# Altium schematic sheet Sheet1.SchDoc
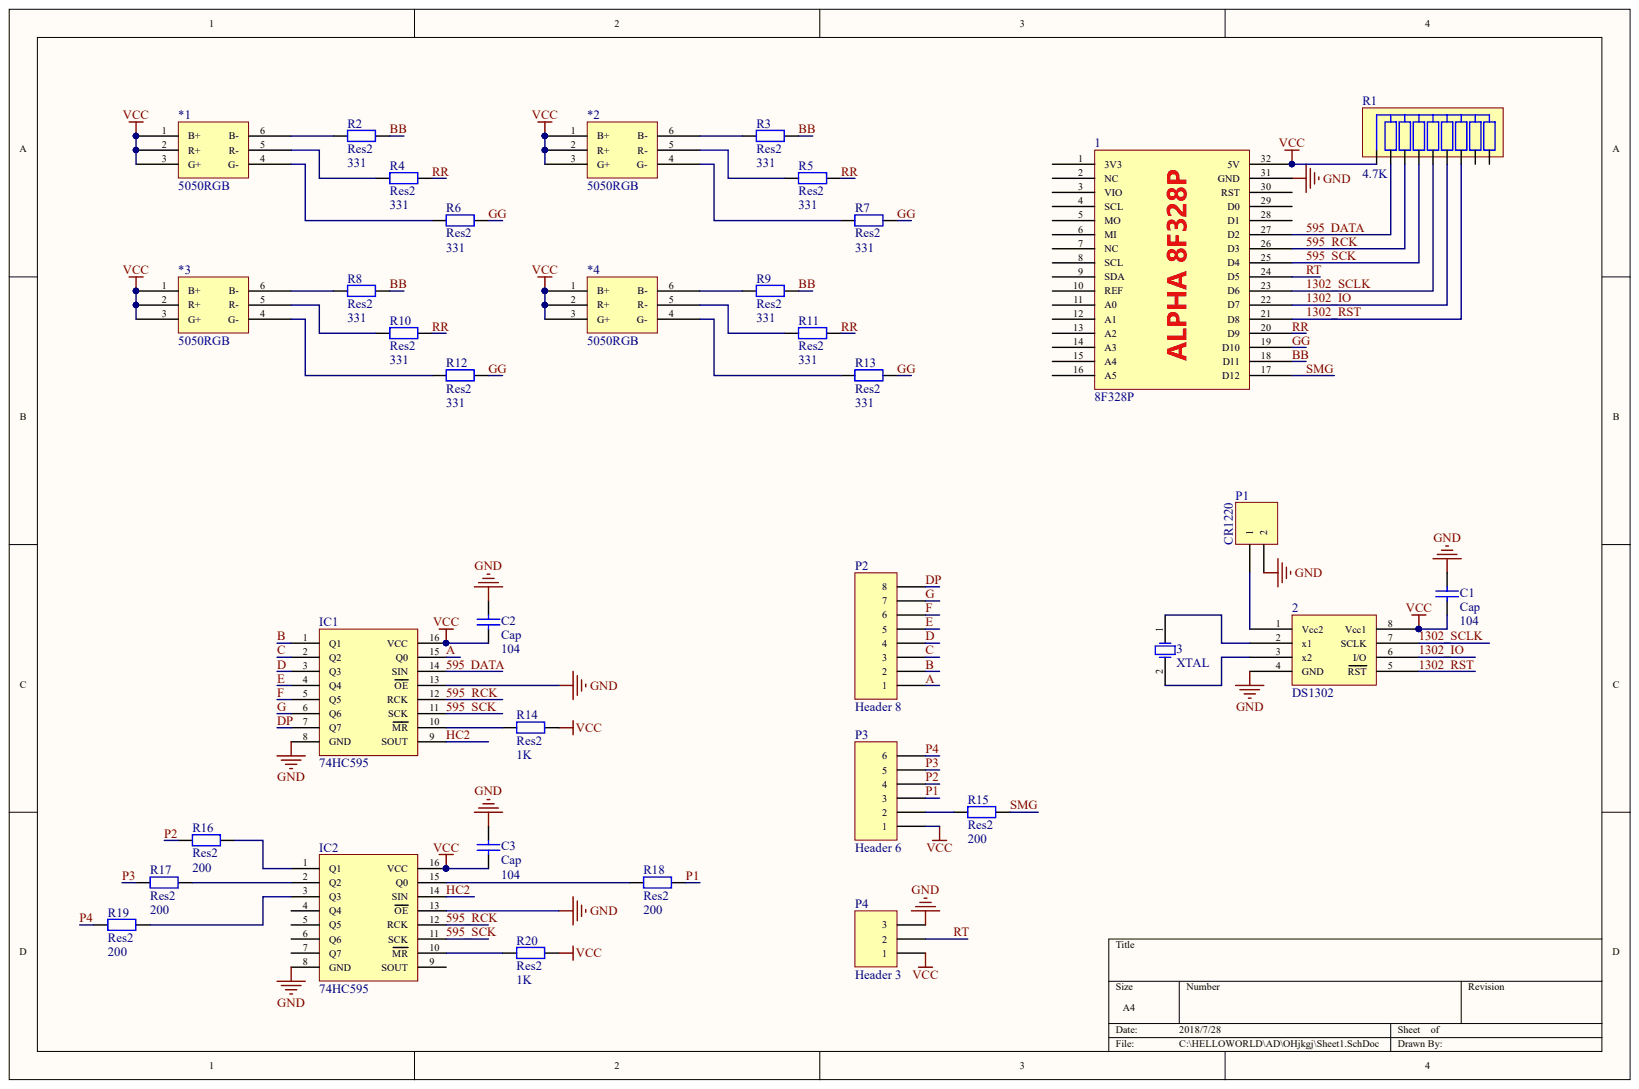
[diagram p0: Sheet1.SchDoc]

## Parts
1  8F328P  [ALPHA_8F328P]
2  DS1302  [DIP-8]
3  XTAL  [R38]
*1  5050RGB  [5050RGBLED]
*2  5050RGB  [5050RGBLED]
*3  5050RGB  [5050RGBLED]
*4  5050RGB  [5050RGBLED]
C1  Cap  value 104  [C104]
C2  Cap  value 104  [C104]
C3  Cap  value 104  [C104]
IC1  74HC595  [DIP-16]
IC2  74HC595  [DIP-16]
P1  CR1220  [CR1220]
P2  Header 8  [HDR1X8]
P3  Header 6  [HDR1X6]
P4  Header 3  [HDR1X3]
R1  4.7K  [HDR1X9]
R2  Res2  [6-0805_N]
R3  Res2  [6-0805_N]
R4  Res2  value 331  [6-0805_N]
R5  Res2  value 331  [6-0805_N]
R6  Res2  value 331  [6-0805_N]
R7  Res2  value 331  [6-0805_N]
R8  Res2  [6-0805_N]
R9  Res2  [6-0805_N]
R10  Res2  value 331  [6-0805_N]
R11  Res2  value 331  [6-0805_N]
R12  Res2  value 331  [6-0805_N]
R13  Res2  value 331  [6-0805_N]
R14  Res2  value 1K  [6-0805_N]
R15  Res2  value 200  [6-0805_N]
R16  Res2  [6-0805_N]
R17  Res2  value 200  [6-0805_N]
R18  Res2  value 200  [6-0805_N]
R19  Res2  value 200  [6-0805_N]
R20  Res2  value 1K  [6-0805_N]

## Nets
GND: 1.31(GND) 2.4(GND) C1.1 C2.1 C3.1 IC1.8(GND) IC1.13(OE) IC2.8(GND) IC2.13(OE) P1.2 P4.3
VCC: 1.32(5V) 2.8(Vcc1) *1.1(B+) *1.2(R+) *1.3(G+) *2.1(B+) *2.2(R+) *2.3(G+) *3.1(B+) *3.2(R+) *3.3(G+) *4.1(B+) *4.2(R+) *4.3(G+) C1.2 C2.2 C3.2 IC1.16(VCC) IC2.16(VCC) P3.1 P4.1 R1.1 R14.2 R20.2
595_DATA: 1.27(D2) IC1.14(SIN) R1.2
595_RCK: 1.26(D3) IC1.12(RCK) IC2.12(RCK) R1.3
595_SCK: 1.25(D4) IC1.11(SCK) IC2.11(SCK) R1.4
1302_IO: 1.22(D7) 2.6(I/O) R1.6
1302_RST: 1.21(D8) 2.5(RST) R1.7
1302_SCLK: 1.23(D6) 2.7(SCLK) R1.5
A: IC1.15(Q0) P2.1
B: IC1.1(Q1) P2.2
BB: 1.18(D11) R2.2 R3.2 R8.2 R9.2
C: IC1.2(Q2) P2.3
D: IC1.3(Q3) P2.4
DP: IC1.7(Q7) P2.8
E: IC1.4(Q4) P2.5
F: IC1.5(Q5) P2.6
G: IC1.6(Q6) P2.7
GG: 1.19(D10) R6.2 R7.2 R12.2 R13.2
HC2: IC1.9(SOUT) IC2.14(SIN)
P1: P3.3 R18.2
P2: P3.4 R16.1
P3: P3.5 R17.1
P4: P3.6 R19.1
RR: 1.20(D9) R4.2 R5.2 R10.2 R11.2
RT: 1.24(D5) P4.2
SMG: 1.17(D12) R15.1
Net2_1: 2.1(Vcc2) P1.1
Net2_2: 2.2(x1) 3.1
Net2_3: 2.3(x2) 3.2
Net*1_4: *1.4(G-) R6.1
Net*1_5: *1.5(R-) R4.1
Net*1_6: *1.6(B-) R2.1
Net*2_4: *2.4(G-) R7.1
Net*2_5: *2.5(R-) R5.1
Net*2_6: *2.6(B-) R3.1
Net*3_4: *3.4(G-) R12.1
Net*3_5: *3.5(R-) R10.1
Net*3_6: *3.6(B-) R8.1
Net*4_4: *4.4(G-) R13.1
Net*4_5: *4.5(R-) R11.1
Net*4_6: *4.6(B-) R9.1
NetIC1_10: IC1.10(MR) R14.1
NetIC2_1: IC2.1(Q1) R16.2
NetIC2_2: IC2.2(Q2) R17.2
NetIC2_3: IC2.3(Q3) R19.2
NetIC2_10: IC2.10(MR) R20.1
NetIC2_15: IC2.15(Q0) R18.1
NetP3_2: P3.2 R15.2

Not connected: 1 1(3V3) 2(NC) 3(VIO) 4(SCL) 5(MO) 6(MI) 7(NC) 8(SCL) 9(SDA) 10(REF) 11(A0) 12(A1) 13(A2) 14(A3) 15(A4) 16(A5) 28(D1) 29(D0) 30(RST); IC2 4(Q4) 5(Q5) 6(Q6) 7(Q7) 9(SOUT); R1 8 9

## Library data of the parts
1 *1-*4 = Component_1: Component Kind: Standard
  1: Library Name: ALPHA_8F328P.SchLib
  *1-*4: Library Name: 5050RGBLED.SchLib
3 = XTAL: Crystal Oscillator; Component Kind: Standard; LatestRevisionDate: 17-Jul-2002; LatestRevisionNote: Re-released for DXP Platform.; Library Name: Miscellaneous Devices.IntLib; PackageDescription: Crystal, Thru-Hole; 2 Leads; Body 3.1 x 8.2 mm (Dia.xH); PackageReference: R38; Published: 8-Jun-2000; Simulation: XTAL; Publisher: Altium Limited
C1-C3 = Cap: Capacitor; Component Kind: Standard; LatestRevisionDate: 17-Jul-2002; LatestRevisionNote: Re-released for DXP Platform.; Library Name: Miscellaneous Devices.IntLib; PackageDescription: Radial Cap, Thru-Hole; 2 Leads; 0.3 in Pin Spacing; PackageReference: RAD-0.3; Published: 8-Jun-2000; Signal Integrity: Cap; Simulation: CAP; Publisher: Altium Limited
IC1 IC2 = 74HC595: 8位移位寄存器; 版权归属: 源创客; BOM名称: 8位移位寄存器; Component Kind: Standard; Library Name: 1.2   - 74系列芯片.SchLib; 学习资源: www.ooocke.com
P1 = Header 2: Header, 2-Pin; Component Kind: Standard; LatestRevisionDate: 17-Jul-2002; LatestRevisionNote: Re-released for DXP Platform.; Library Name: Miscellaneous Connectors.IntLib; Signal Integrity: Connector; Publisher: Altium Limited
P2 = Header 8: Header, 8-Pin; Component Kind: Standard; LatestRevisionDate: 17-Jul-2002; LatestRevisionNote: Re-released for DXP Platform.; Library Name: Miscellaneous Connectors.IntLib; Signal Integrity: Connector; Publisher: Altium Limited
P3 = Header 6: Header, 6-Pin; Component Kind: Standard; LatestRevisionDate: 17-Jul-2002; LatestRevisionNote: Re-released for DXP Platform.; Library Name: Miscellaneous Connectors.IntLib; Signal Integrity: Connector; Publisher: Altium Limited
P4 = Header 3: Header, 3-Pin; Component Kind: Standard; LatestRevisionDate: 17-Jul-2002; LatestRevisionNote: Re-released for DXP Platform.; Library Name: Miscellaneous Connectors.IntLib; Signal Integrity: Connector; Publisher: Altium Limited
R1 = R SIP9: 单列直插排阻; 版权归属: 源创客; BOM名称: 单列直插排阻; Component Kind: Standard; Library Name: 3.1   - 电阻-电容-电感.SchLib; 学习资源: www.ooocke.com
R2 R3 R8 R9 = Res2: Resistor; Value: 331; Component Kind: Standard; LatestRevisionDate: 17-Jul-2002; LatestRevisionNote: Re-released for DXP Platform.; Library Name: Miscellaneous Devices.IntLib; PackageDescription: Axial Device, Thru-Hole; 2 Leads; 0.4 in Pin Spacing; PackageReference: AXIAL-0.4; Published: 8-Jun-2000; Signal Integrity: Res; Simulation: RESISTOR; Publisher: Altium Limited
R4-R7 R10-R15 R17-R20 = Res2: Resistor; Component Kind: Standard; LatestRevisionDate: 17-Jul-2002; LatestRevisionNote: Re-released for DXP Platform.; Library Name: Miscellaneous Devices.IntLib; PackageDescription: Axial Device, Thru-Hole; 2 Leads; 0.4 in Pin Spacing; PackageReference: AXIAL-0.4; Published: 8-Jun-2000; Signal Integrity: Res; Simulation: RESISTOR; Publisher: Altium Limited
R16 = Res2: Resistor; Value: 200; Component Kind: Standard; LatestRevisionDate: 17-Jul-2002; LatestRevisionNote: Re-released for DXP Platform.; Library Name: Miscellaneous Devices.IntLib; PackageDescription: Axial Device, Thru-Hole; 2 Leads; 0.4 in Pin Spacing; PackageReference: AXIAL-0.4; Published: 8-Jun-2000; Signal Integrity: Res; Simulation: RESISTOR; Publisher: Altium Limited
2 = DS1302: Component Kind: Standard; Library Name: 时钟芯片.SchLib
